# Altium schematic sheet MotorDriver_BrushedMotor_IO.SchDoc
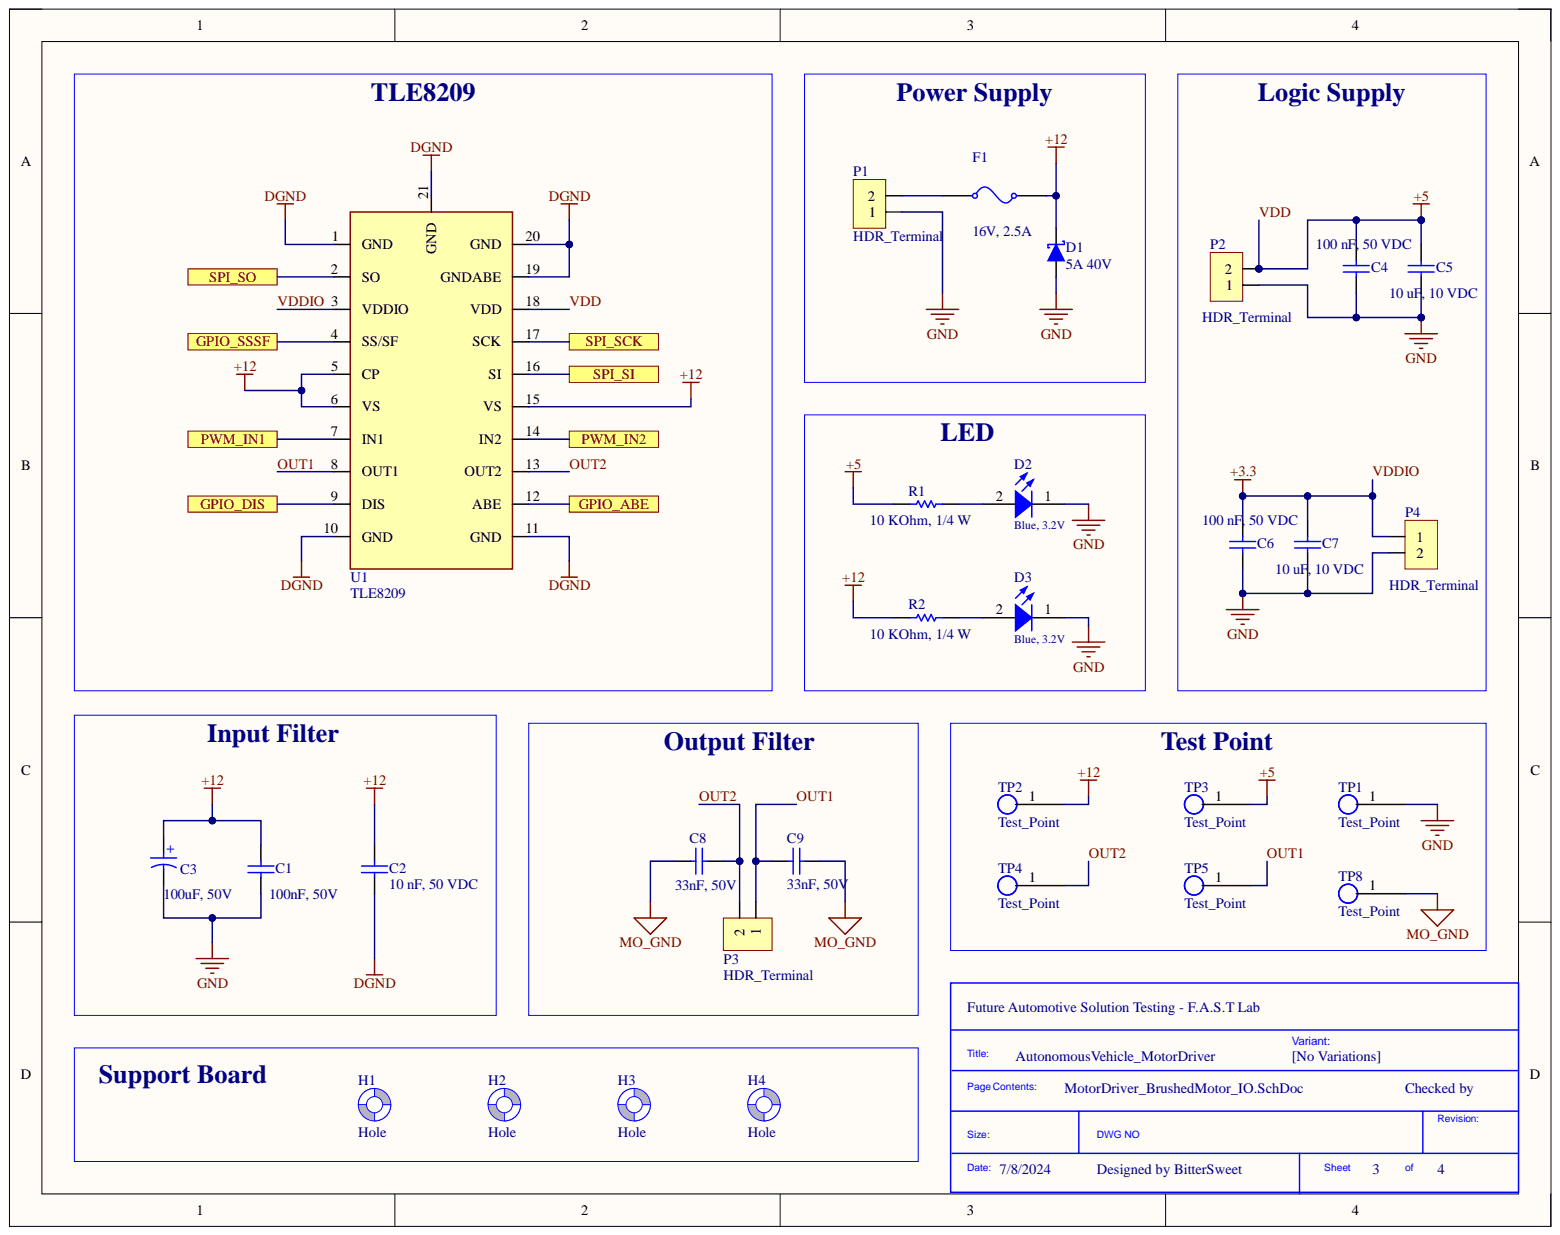
[diagram p0: MotorDriver_BrushedMotor_IO.SchDoc]

## Parts
C1  100nF, 50V  [CAP_RADIAL_2.54]
C2  10 nF, 50 VDC  [CAP_0805]
C3  100uF, 50V  [WCAP-ASLI_D8H10.5]
C4  100 nF, 50 VDC  [CAP_0603]
C5  10 uF, 10 VDC  Voltage Rating DC: 10 VDC  [CAP_0603]
C6  100 nF, 50 VDC  [CAP_0603]
C7  10 uF, 10 VDC  Voltage Rating DC: 10 VDC  [CAP_0603]
C8  33nF, 50V  [CAP_RADIAL_2.54]
C9  33nF, 50V  [CAP_RADIAL_2.54]
D1  5A 40V  [DO-214AB, SMC]
D2  Blue, 3.2V  [WL-SMCW_0603_H=0.7mm]
D3  Blue, 3.2V  [WL-SMCW_0603_H=0.7mm]
F1  16V, 2.5A  Hold Current: 2.5A  [Fuse_SMD_1812]
H1  Hole  [Hole_3.2mm]
H2  Hole  [Hole_3.2mm]
H3  Hole  [Hole_3.2mm]
H4  Hole  [Hole_3.2mm]
P1  HDR_Terminal  [KF-5.08-2-R]
P2  HDR_Terminal  [HDR_HEADER_2.0_HORIZONTAL]
P3  HDR_Terminal  [KF-5.08-2-R]
P4  HDR_Terminal  [HDR_HEADER_2.0_HORIZONTAL]
R1  10 KOhm, 1/4 W  Rated Power: 1/4 W  [resistor_0603]
R2  10 KOhm, 1/4 W  Rated Power: 1/4 W  [resistor_0603]
TP1  Test_Point  [TP_1_5mm_SMD]
TP2  Test_Point  [TP_1_5mm_SMD]
TP3  Test_Point  [TP_1_5mm_SMD]
TP4  Test_Point  [TP_1_5mm_SMD]
TP5  Test_Point  [TP_1_5mm_SMD]
TP8  Test_Point  [TP_1_5mm_SMD]
U1  TLE8209  [TLE8209_2E_PG-DSO-20-71]

## Ports
GPIO_ABE, GPIO_DIS, GPIO_SSSF, PWM_IN1, PWM_IN2, SPI_SCK, SPI_SI, SPI_SO

## Nets
+12: C1.2 C2.2 C3.1 D1.2 F1.1 R2.2 TP2.1 U1.5(CP) U1.6(VS) U1.15(VS)
DGND: C2.1 U1.1(GND) U1.10(GND) U1.11(GND) U1.19(GNDABE) U1.20(GND) U1.21(GND)
GND: C1.1 C3.2 C4.1 C5.1 C6.1 C7.1 D1.1 D2.1 D3.1 P1.1 P2.1 P4.2 TP1.1
MO_GND: C8.2 C9.2 TP8.1
GPIO_ABE: U1.12(ABE) ; port GPIO_ABE
GPIO_DIS: U1.9(DIS) ; port GPIO_DIS
GPIO_SSSF: U1.4(SS/SF) ; port GPIO_SSSF
OUT1: C9.1 P3.1 TP5.1 U1.8(OUT1)
OUT2: C8.1 P3.2 TP4.1 U1.13(OUT2)
PWM_IN1: U1.7(IN1) ; port PWM_IN1
PWM_IN2: U1.14(IN2) ; port PWM_IN2
SPI_SCK: U1.17(SCK) ; port SPI_SCK
SPI_SI: U1.16(SI) ; port SPI_SI
SPI_SO: U1.2(SO) ; port SPI_SO
VDD: C4.2 C5.2 P2.2 R1.2 TP3.1 U1.18(VDD)
VDDIO: C6.2 C7.2 P4.1 U1.3(VDDIO)
NetD2_2: D2.2 R1.1
NetD3_2: D3.2 R2.1
NetF1_0: F1.0 P1.2

Not connected: H1 1; H2 1; H3 1; H4 1

## Library data of the parts
C1 C8 C9 = Capacitor: Component Kind: Standard; Description: Capacitor; Library Name: AutonomousVehicle_Lib.IntLib
  C1: URL: https://www.thegioiic.com/product/tu-gom-xuyen-lo?prid=6863
  C8 C9: URL: https://www.thegioiic.com/product/tu-gom-xuyen-lo?prid=6856
C2 = 0108051031050: CAP, size 0805, 10 nF, 50 VDC; Manufacture Name: TDK; Manufacture SN: CGA4C2C0G1H103J060AA; Capacitance: 10 nF; Tolerance: +/-5%; Voltage Rating DC: 50 VDC; Component Kind: Standard; ComponentLink2Description: Datasheet; ComponentLink2URL: https://product.tdk.com/system/files/dam/doc/product/capacitor/ceramic/mlcc/catalog/mlcc_automotive_general_en.pdf?ref_disty=mouser; Library Name: Altium_Ceramic_Cap.IntLib; Mounting Technology: SMT; Operating Temperature: -55 to +125degC; Packaging: Tape and Reel; Size: 0805; Supplier 1 Name: Mouser; Supplier 1 SN: 810-CGA4C2C0G1H103J; Supplier 1 URL: https://www.mouser.vn/ProductDetail/TDK/CGA4C2C0G1H103J060AA?qs=FxQuwy19cyC9M6v0nMqjhA%3D%3D&utm_source=mouser-refine-download&utm_medium=file&utm_campaign=CGA4C2C0G1H103J060AA&utm_content=TDK; Supplier 2 Name: Digi-Key; Supplier 2 SN: 445-6947-2-ND; Supplier 2 URL: https://www.digikey.com/en/products/detail/tdk-corporation/cga4c2c0g1h103j060aa/2672840; Supplier 3 Name: thegioiic; Supplier 3 SN: OEM; Supplier 3 URL: N/A; Value: 10 nF, 50 VDC
C3 = 865080653016: V-Chip, D8 x H10.5mm, 100uF, 50V; Manufacturer: Wurth Elektronik; Capacitance Tolerance: +/-20%; Dissipation Factor: 12%; Endurance: 2000h; Leakage Current: 50uA; Ripple Current: 350mA; Capacitance: 100uF; Category: Aluminum Electrolytic Capacitors; Component Kind: Standard; ComponentLink2Description: Datasheet Link; ComponentLink2URL: https://www.we-online.com/redexpert/spec/865080653016?ad; Diameter: 8mm; Height: 10.5mm; Manufacturer Part Number: N/A; Mount: Surface Mount; Operating Temperature Max: 105°C; Operating Temperature Min: -55°C; Packaging: Tape and Reel; Rated Voltage (DC): 50V; Supplier 1 Name: Mouser; Supplier 1 SN: 710-865080653016; Supplier 1 URL: https://www.mouser.vn/ProductDetail/Wurth-Elektronik/865080653016?qs=0KOYDY2FL2%2FUDYNkMs7i0Q%3D%3D; Supplier 2 Name: Digi-Key; Supplier 2 SN: N/A; Supplier 2 URL: N/A; Supplier 3 Name: thegioiic; Supplier 3 SN: N/A; Supplier 3 URL: https://www.thegioiic.com/tu-nhom-smd-100uf-50v-8x10-5mm; Value: 100uF, 50V; Library Name: Altium_Alutinum_Cap.IntLib
C4 C6 = 0106031041050: CAP, size 0603, 100 nF, 50 VDC; Manufacture Name: TDK; Manufacture SN: CGA3E3X8R1H104K080AE; Capacitance: 100 nF; Tolerance: +/-10%; Voltage Rating DC: 50 VDC; Component Kind: Standard; ComponentLink2Description: Datasheet; ComponentLink2URL: https://product.tdk.com/system/files/dam/doc/product/capacitor/ceramic/mlcc/catalog/mlcc_automotive_soft_en.pdf?ref_disty=mouser; Library Name: Altium_Ceramic_Cap.IntLib; Mounting Technology: SMT; Operating Temperature: -55 to +125degC; Packaging: Tape and Reel; Size: 0603; Supplier 1 Name: Mouser; Supplier 1 SN: 810-CGA3E3X8R1H104KA; Supplier 1 URL: https://www.mouser.com/ProductDetail/TDK/CGA3E3X8R1H104K080AE?qs=nQSIdc08i%252Bd8g3IRRgU2bw%3D%3D&utm_source=mouser-refine-download&utm_medium=file&utm_campaign=CGA3E3X8R1H104K080AE&utm_content=TDK; Supplier 2 Name: Digi-Key; Supplier 2 SN: 445-173697-2-ND; Supplier 2 URL: https://www.digikey.com/en/products/detail/tdk-corporation/cga3e3x8r1h104k080ae/5809262; Supplier 3 Name: thegioiic; Supplier 3 SN: N/A; Supplier 3 URL: N/A; Value: 100 nF, 50 VDC
C5 C7 = 0106031061010: CAP, size 0603, 10 uF, 10 VDC; Manufacture Name: Samsung Electro-Mechanics; Manufacture SN: CL10A106KP8NNWC; Capacitance: 10 uF; Tolerance: +/-10%; Component Kind: Standard; ComponentLink2Description: Datasheet; ComponentLink2URL: https://www.mouser.vn/datasheet/2/585/MLCC-1837944.pdf; Library Name: Altium_Ceramic_Cap.IntLib; Mounting Technology: SMT; Operating Temperature: -55 to +125degC; Packaging: Tape and Reel; Size: 0603; Supplier 1 Name: Mouser; Supplier 1 SN: 187-CL10A106KP8NNWC; Supplier 1 URL: https://www.mouser.vn/ProductDetail/Samsung-Electro-Mechanics/CL10A106KP8NNWC?qs=xZ%2FP%252Ba9zWqZx8LSX23YqWA%3D%3D&utm_source=mouser-refine-download&utm_medium=file&utm_campaign=CL10A106KP8NNWC&utm_content=Samsung-Electro-Mechanics; Supplier 2 Name: Digi-Key; Supplier 2 SN: 1276-CL10A106KP8NNWCTR-ND; Supplier 2 URL: https://www.digikey.com/en/products/detail/samsung-electro-mechanics/cl10a106kp8nnwc/16572907; Supplier 3 Name: thegioiic; Supplier 3 SN: N/A; Supplier 3 URL: https://www.thegioiic.com/tu-gom-0603-10uf-25v; Value: 10 uF, 10 VDC
D1 = Diode_Schottky: SS54 SMC Diode Schottky 5A 40V; Component Kind: Standard; Library Name: AutonomousVehicle_Lib.IntLib
D2 D3 = 160603BlueClear: WL-SMCW SMT Mono-color Chip LED Waterclear, size 0603, Blue, 3.2V, 140deg; Type: Clear; Color: Blue; Component Kind: Standard; ComponentLink1Description: Datasheet link; ComponentLink1URL: N/A; ComponentLink2Description: Supplier 1 URL; ComponentLink2URL: https://www.mouser.vn/ProductDetail/Wurth-Elektronik/150060BS75003?qs=Li%252BoUPsLEnu8LvIbM48DKA%3D%3D; ComponentLink3Description: Supplier 2 URL; ComponentLink3URL: https://www.digikey.com/en/products/detail/liteon/LTST-C191TBKT/388530; ComponentLink4Description: Supplier 3 URL; ComponentLink4URL: https://www.thegioiic.com/led-xanh-duong-0603-dan-smd-trong-suot; Library Name: Altium_LED.IntLib; Size: 0603; Supplier 1 Name: Mouser; Supplier 1 SN: 710-150060GS75000; Supplier 1 URL: https://www.mouser.vn/ProductDetail/Wurth-Elektronik/150060BS75003?qs=Li%252BoUPsLEnu8LvIbM48DKA%3D%3D; Supplier 2 Name: Digi-Key; Supplier 2 SN: 160-1647-2-ND; Supplier 2 URL: https://www.digikey.com/en/products/detail/liteon/LTST-C191TBKT/388530; Supplier 3 Name: ICDayRoi; Supplier 3 SN: N/A; Supplier 3 URL: https://www.thegioiic.com/led-xanh-duong-0603-dan-smd-trong-suot; Value: Blue, 3.2V
F1 = 061812250016: Resettable Fuse, Size 1812, 16V, 2.5A; Manufacturer: Bourns; Threshold Current: 100A; Trip Current: 5A; Component Kind: Standard; ComponentLink1Description: Datasheet link; ComponentLink1URL: https://www.bourns.com/docs/Product-Datasheets/mfnsmf.pdf; ComponentLink2Description: Supplier 1 URL; ComponentLink2URL: https://www.mouser.vn/ProductDetail/Bourns/MF-MSMF250-16X-2?qs=9fn1gpisni78zr7pGyDhCQ%3D%3D; ComponentLink3Description: Supplier 2 URL; ComponentLink3URL: https://www.digikey.com/en/products/detail/bourns-inc/MF-MSMF250-16X-2/2563289; ComponentLink4Description: Supplier 3 URL; ComponentLink4URL: https://www.thegioiic.com/mf-msmf250-16-cau-chi-tu-phuc-hoi-1812-16v-2-5a; Library Name: Altium_Fuse.IntLib; Mounting Technology: SMT/SMD; Size: 1812; Supplier 1 Name: Mouser; Supplier 1 SN: 652-MF-MSMF250/16X-2; Supplier 1 URL: https://www.mouser.vn/ProductDetail/Bourns/MF-MSMF250-16X-2?qs=9fn1gpisni78zr7pGyDhCQ%3D%3D; Supplier 2 Name: Digi-Key; Supplier 2 SN: MF-MSMF250/16X-2TR-ND; Supplier 2 URL: https://www.mouser.vn/ProductDetail/Bourns/MF-MSMF250-16X-2?qs=9fn1gpisni78zr7pGyDhCQ%3D%3D; Supplier 3 Name: thegioiic; Supplier 3 SN: MF-MSMF250/16; Supplier 3 URL: https://www.thegioiic.com/mf-msmf250-16-cau-chi-tu-phuc-hoi-1812-16v-2-5a; Threshold Voltage: 16V; Value: 16V, 2.5A
P1-P4 = HDR_Terminal: HDR_Terminal 2 pin; Component Kind: Standard; ComponentLink1Description: URL; ComponentLink1URL: https://www.thegioiic.com/kf128-2-v-domino-2-chan-thang-3-5mm-300v-10a-han-pcb; Supplier: ThegioiIC; Library Name: AutonomousVehicle_Lib.IntLib
R1 R2 = 0006031031: RES, size 0603, 10 KOhm, 1/4 W; Manufacture  Name: OEM; Resistance: 10 KOhm; Resistance Tolerance: +/-1%; Component Kind: Standard; ComponentLink1Description: Datasheet link; ComponentLink1URL: https://fscdn.rohm.com/en/products/databook/datasheet/passive/resistor/chip_resistor/esr-e.pdf; ComponentLink2Description: Supplier 1 URL; ComponentLink2URL: https://www.thegioiic.com/dien-tro-10-kohm-0603-1-; ComponentLink3Description: Supplier 2 URL; ComponentLink3URL: https://www.digikey.com/en/products/detail/rohm-semiconductor/ESR03EZPF1002/1983429; ComponentLink4Description: Supplier 3 URL; ComponentLink4URL: N/A; Library Name: Altium_Resistor.IntLib; Manufacture  SN: OEM; Mounting Technology: SMT; Size: 0603; Supplier 1 Name: Mouser; Supplier 1 SN: 755-ESR03EZPF1002; Supplier 1 URL: https://www.mouser.vn/ProductDetail/ROHM-Semiconductor/ESR03EZPF1002?qs=sGAEpiMZZMvdGkrng054t67Efho1F8r6CDeAsqwFse8%3D; Supplier 2 Name: Digi-Key; Supplier 2 SN: RHM10KADTR-ND RHM10KADCT-ND RHM10KADDKR-ND; Supplier 2 URL: https://www.digikey.com/en/products/detail/rohm-semiconductor/ESR03EZPF1002/1983429; Supplier 3 Name: thegioiic; Supplier 3 SN: N/A; Supplier 3 URL: N/A; Value: 10 KOhm, 1/4 W
U1 = TLE8209: SPI Programmable H-Bridge, Automotive Application for Motor Control, Throttle Control,...; Component Kind: Standard; Library Name: AutonomousVehicle_Lib.IntLib
H1-H4 = Hole: Component Kind: Standard; Description: Hole; Library Name: AutonomousVehicle_Lib.IntLib
TP1-TP5 TP8 = Test_Point: Component Kind: Standard; Description: Test_Point; Library Name: AutonomousVehicle_Lib.IntLib
